# Altium schematic sheet MCU_USB.SchDoc
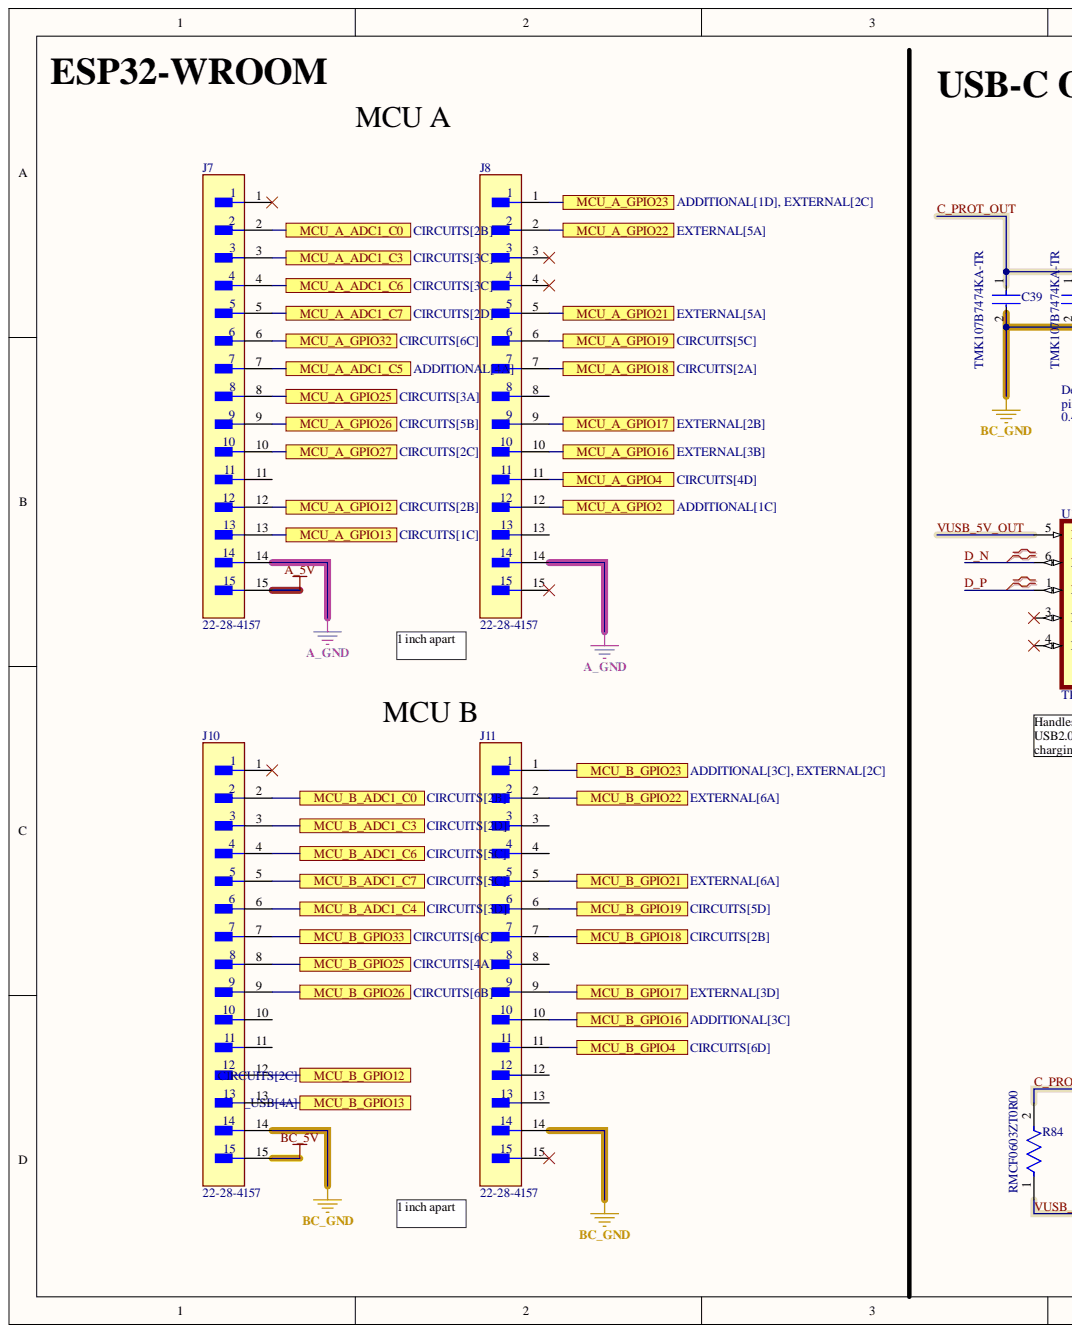
[diagram p0: MCU_USB.SchDoc, left]
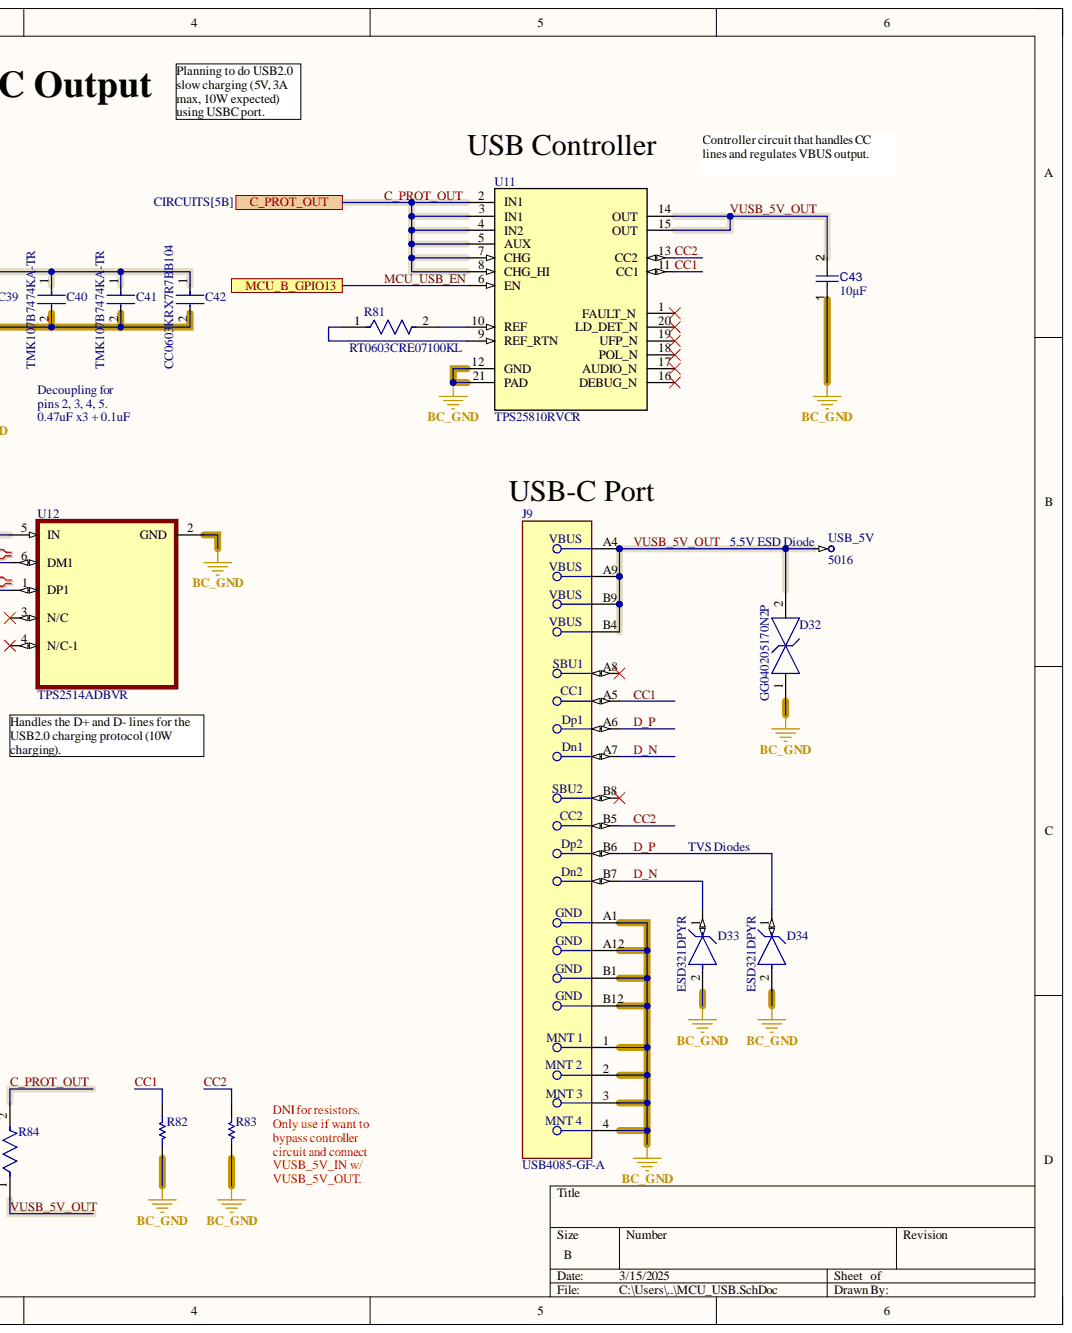
[diagram p1: MCU_USB.SchDoc, right]

## Parts
C39  TMK107B7474KA-TR  [FP-0603-L_1_6_0_1-W_0_8_0-MFG]
C40  TMK107B7474KA-TR  [FP-0603-L_1_6_0_1-W_0_8_0-MFG]
C41  TMK107B7474KA-TR  [FP-0603-L_1_6_0_1-W_0_8_0-MFG]
C42  CC0603KRX7R7BB104  [FP-CC0603-DA-MFG]
C43  value 10µF  [CAPC0603(1608)100_L]  (hidden comment: Capacitor 10µF +/-20% 10V 0603)
D32  GG040205170N2P  [FP-GG0402-MFG]
D33  ESD321DPYR  [FP-DPY0002A-MFG]
D34  ESD321DPYR  [FP-DPY0002A-MFG]
J7  22-28-4157  [FP-22-28-4157-MFG]
J8  22-28-4157  [FP-22-28-4157-MFG]
J9  USB4085-GF-A  [FP-USB4085-GF-A-MFG]
J10  22-28-4157  [FP-22-28-4157-MFG]
J11  22-28-4157  [FP-22-28-4157-MFG]
R81  RT0603CRE07100KL  [FP-RT0603-MFG]
R82  RG1608N-512-W-T1  [RESC1608X50X30LL20T20]  (value not drawn)
R83  RG1608N-512-W-T1  [RESC1608X50X30LL20T20]  (value not drawn)
R84  RMCF0603ZT0R00  [FP-RMCF0603-MFG]
U11  TPS25810RVCR  [RVC0020A]
U12  TPS2514ADBVR  [SOT95P280X145-6N]
USB_5V  5016  [KEYSTONE-5016_V]

## Ports
C_PROT_OUT, MCU_A_ADC1_C0, MCU_A_ADC1_C3, MCU_A_ADC1_C5, MCU_A_ADC1_C6, MCU_A_ADC1_C7, MCU_A_GPIO2, MCU_A_GPIO4, MCU_A_GPIO12, MCU_A_GPIO13, MCU_A_GPIO16, MCU_A_GPIO17, MCU_A_GPIO18, MCU_A_GPIO19, MCU_A_GPIO21, MCU_A_GPIO22, MCU_A_GPIO23, MCU_A_GPIO25, MCU_A_GPIO26, MCU_A_GPIO27, MCU_A_GPIO32, MCU_B_ADC1_C0, MCU_B_ADC1_C3, MCU_B_ADC1_C4, MCU_B_ADC1_C6, MCU_B_ADC1_C7, MCU_B_GPIO4, MCU_B_GPIO12, MCU_B_GPIO13, MCU_B_GPIO16, MCU_B_GPIO17, MCU_B_GPIO18, MCU_B_GPIO19, MCU_B_GPIO21, MCU_B_GPIO22, MCU_B_GPIO23, MCU_B_GPIO25, MCU_B_GPIO26, MCU_B_GPIO33

## Nets
A_5V: J7.15
A_GND: J7.14 J8.14
BC_5V: J10.15
BC_GND: C39.2 C40.2 C41.2 C42.2 C43.1 D32.1 D33.2 D34.2 J9.1 J9.2 J9.3 J9.4 J9.A1 J9.A12 J9.B1 J9.B12 J10.14 J11.14 R82.1 R83.1 U11.12(GND) U11.21(PAD) U12.2(GND)
C_PROT_OUT: C39.1 C40.1 C41.1 C42.1 R84.2 U11.2(IN1) U11.3(IN1) U11.4(IN2) U11.5(AUX) U11.7(CHG) U11.8(CHG_HI) ; port C_PROT_OUT
CC1: J9.A5 R82.2 U11.11(CC1)
CC2: J9.B5 R83.2 U11.13(CC2)
D_N: D33.1 J9.A7 J9.B7 U12.6(DM1)
D_P: D34.1 J9.A6 J9.B6 U12.1(DP1)
MCU_A_ADC1_C0: J7.2 ; port MCU_A_ADC1_C0
MCU_A_ADC1_C3: J7.3 ; port MCU_A_ADC1_C3
MCU_A_ADC1_C5: J7.7 ; port MCU_A_ADC1_C5
MCU_A_ADC1_C6: J7.4 ; port MCU_A_ADC1_C6
MCU_A_ADC1_C7: J7.5 ; port MCU_A_ADC1_C7
MCU_A_GPIO2: J8.12 ; port MCU_A_GPIO2
MCU_A_GPIO4: J8.11 ; port MCU_A_GPIO4
MCU_A_GPIO12: J7.12 ; port MCU_A_GPIO12
MCU_A_GPIO13: J7.13 ; port MCU_A_GPIO13
MCU_A_GPIO16: J8.10 ; port MCU_A_GPIO16
MCU_A_GPIO17: J8.9 ; port MCU_A_GPIO17
MCU_A_GPIO18: J8.7 ; port MCU_A_GPIO18
MCU_A_GPIO19: J8.6 ; port MCU_A_GPIO19
MCU_A_GPIO21: J8.5 ; port MCU_A_GPIO21
MCU_A_GPIO22: J8.2 ; port MCU_A_GPIO22
MCU_A_GPIO23: J8.1 ; port MCU_A_GPIO23
MCU_A_GPIO25: J7.8 ; port MCU_A_GPIO25
MCU_A_GPIO26: J7.9 ; port MCU_A_GPIO26
MCU_A_GPIO27: J7.10 ; port MCU_A_GPIO27
MCU_A_GPIO32: J7.6 ; port MCU_A_GPIO32
MCU_B_ADC1_C0: J10.2 ; port MCU_B_ADC1_C0
MCU_B_ADC1_C3: J10.3 ; port MCU_B_ADC1_C3
MCU_B_ADC1_C4: J10.6 ; port MCU_B_ADC1_C4
MCU_B_ADC1_C6: J10.4 ; port MCU_B_ADC1_C6
MCU_B_ADC1_C7: J10.5 ; port MCU_B_ADC1_C7
MCU_B_GPIO4: J11.11 ; port MCU_B_GPIO4
MCU_B_GPIO12: J10.12 ; port MCU_B_GPIO12
MCU_B_GPIO16: J11.10 ; port MCU_B_GPIO16
MCU_B_GPIO17: J11.9 ; port MCU_B_GPIO17
MCU_B_GPIO18: J11.7 ; port MCU_B_GPIO18
MCU_B_GPIO19: J11.6 ; port MCU_B_GPIO19
MCU_B_GPIO21: J11.5 ; port MCU_B_GPIO21
MCU_B_GPIO22: J11.2 ; port MCU_B_GPIO22
MCU_B_GPIO23: J11.1 ; port MCU_B_GPIO23
MCU_B_GPIO25: J10.8 ; port MCU_B_GPIO25
MCU_B_GPIO26: J10.9 ; port MCU_B_GPIO26
MCU_B_GPIO33: J10.7 ; port MCU_B_GPIO33
MCU_USB_EN: J10.13 U11.6(EN) ; port MCU_B_GPIO13
VUSB_5V_OUT: C43.2 D32.2 J9.A4 J9.A9 J9.B4 J9.B9 R84.1 U11.14(OUT) U11.15(OUT) U12.5(IN) USB_5V.1
NetR81_1: R81.1 U11.9(REF_RTN)
NetR81_2: R81.2 U11.10(REF)

Not connected: J7 1 11; J8 3 4 8 13 15; J9 A8 B8; J10 1 10 11; J11 3 4 8 12 13 15; U11 1(FAULT_N) 16(DEBUG_N) 17(AUDIO_N) 18(POL_N) 19(UFP_N) 20(LD_DET_N); U12 3(N/C) 4(N/C-1)

## Library data of the parts
C39-C41 = CMP-14477-003640-2: CAP CER 0.47UF 25V X7R 0603; Capacitance: 470nF; Tolerance: 10%; Type: General Purpose; Case Code (Imperial): 0603; Case Code (Metric): 1608; Case/Package: 0603; Component Kind: Standard; Depth: 0.8mm; Dielectric: X7R; Halogen Free: Halogen Free; Height: 0.8mm; Lead Free: Lead Free; Length: 1.6mm; Library Name: Altium Content Vault; Max Operating Temperature: 125°C; Min Operating Temperature: -55°C; Mount: Surface Mount; Number of Pins: 2; Package Quantity: 4000; Packaging: Cut Tape; Radiation Hardening: No; Resistor Type: Ceramic; RoHS Compliant: Yes; Series: M; Termination: Standard; Thickness: 0.035inch; Voltage: 25V; Voltage Rating: 25V; Voltage Rating (DC): 25V; Weight: 7.1E-05oz; Width: 0.8mm
C42 = CMP-2000-05002-2: CAP CER 0.1UF 16V X7R 0603; Manufacturer: YAGEO; Capacitance: 100 nF; Material: Ceramic; Temperature Coefficient: 15%; Tolerance: 0.1; Value: 100 nF; Automotive: No; Category: Cap; Component Kind: Standard; ComponentLink2Description: Datasheet; ComponentLink2URL: http://www.yageo.com/documents/recent/UPY-GPHC_X7R_6.3V-to-50V_18.pdf; Device Class L1: Passive Components; Device Class L2: Capacitors; Device Class L3: Ceramic Capacitors; Digikey Description: CAP CER 0.1UF 16V X7R 0603; Footprint Url: http://www.yageo.com/exep/pages/download/literatures/UPY-C_GEN_24.pdf; Height: 0.9mm; Lead Free: Yes; Library Name: Altium Content Vault; Manufacturer Part Number: CC0603KRX7R7BB104; Mouser Description: Multilayer Ceramic Capacitor, CC Series, 0.1 - F, - 10%, X7R, 16 V, 0603 [1608 Metric]; Octopart: https://octopart.com/cc0603krx7r7bb104-yageo-192941; Package: CC0603-DA; RoHS: TRUE; Temperature: -55°C to +125°C; Temperature Characteristic: X7R; Temperature Range High: +125°C; Temperature Range Low: -55°C; Voltage: 16 V; Voltage Rating: 16 V
C43 = CMP-001-00075-4: Chip Capacitor, 10µF +/-20%, 10V, 0603, Thickness 1 mm; Tolerance: 10%; Case/Package: 0603; Component Kind: Standard; Max Operating Temperature: 85°C; Min Operating Temperature: -55°C; Mounting Technology: SMT; Pins: 2; Voltage Rating: 10V; Library Name: PCB Lab - Cooper Union
D32 = CMP-04587-000002-1: TVS DIODE 5V 10V 0402; Manufacturer: AVX; Breakdown Voltage: 5.1V; Capacitance: 17pF; Direction: Bidirectional; Number Of Channels: 1; Peak Pulse Current: 8A; Peak Pulse Power: 80W; Value: 17pF; Automotive: No; Category: Diode; Clamping Voltage: 10V; Component Kind: Standard; ComponentLink2Description: Datasheet; ComponentLink2URL: http://datasheets.avx.com/AVX-GiGuard.pdf; Device Class L1: Circuit Protection; Device Class L2: TVS Diodes; Device Class L3: unset; Digikey Description: TVS DIODE 5V 10V 0402; Height: 0.55mm; Ipc Land Pattern Name: DIOC10060X50; Lead Free: Yes; Library Name: Altium Content Vault; Manufacturer Part Number: GG040205170N2P; Mouser Description: ESD Suppressor TVS Bi-Dir 5V 2-Pin Case 0402(1006Metric) T/R; Octopart: https://octopart.com/gg040205170n2p-avx-81066082; Package: GG0402; Reverse Standoff Voltage: 5V; RoHS: TRUE; Temperature: -55°C to +125°C; Temperature Range High: +125°C; Temperature Range Low: -55°C
D33 D34 = CMP-04989-000001-1: HDMI2.0 ESD PROTECTION; Manufacturer: Texas Instruments; Breakdown Voltage: 4.5V; Capacitance: 0.9pF; Clamping Voltage: 6.8V; Direction: Unidirectional; Number Of Channels: 1; Peak Pulse Current: 6A; Peak Pulse Power: 40W; Reverse Standoff Voltage: 3.6V; Standoff Height: 0mm; Value: 0.9pF; Ambient Temperature Range High: +125°C; Ambient Temperature Range Low: -40°C; Automotive: No; Category: Diode; Component Kind: Standard; ComponentLink2Description: Datasheet; ComponentLink2URL: http://www.ti.com/lit/ds/symlink/esd321.pdf; Device Class L1: Circuit Protection; Device Class L2: TVS Diodes; Device Class L3: unset; Digikey Description: HDMI2.0 ESD PROTECTION; Height: 0.45mm; Lead Free: Yes; Library Name: Altium Content Vault; Manufacturer Part Number: ESD321DPYR; Octopart: https://octopart.com/esd321dpyr-texas+instruments-94354200; Package: DPY0002A; RoHS: TRUE; Temperature: -40°C to +125°C; Temperature Range High: +125°C; Temperature Range Low: -40°C
J7 J8 J10 J11 = CMP-04776-000945-1: KK 100 HDR ASSY BKWY 15 CKT 30 S; Connector Type: Male Pin; Contact Resistance: 20mR; Current Rating: 4A; Gender: Male; Glow Wire Compliant: Non-compliant; Max Voltage Rating (DC): 250.25kV; Number of Circuits: 15; Pitch: 2.54mm; Voltage Rating: 250V; Color: Black; Component Kind: Standard; Contact Material: Brass; Contact Mating Length: 0.32inch; Contact Plating: Gold; Contact Type: Male Pin; Housing Color: Black; Housing Material: Thermoplastic; Lead Free: Lead Free; Library Name: Altium Content Vault; Max Operating Temperature: 75°C; Min Operating Temperature: 0°C; Mount: Through Hole; Number of Contacts: 15; Number of Positions: 15; Number of Rows: 1; Orientation: Straight; Package Quantity: 1; Packaging: Bulk; Series: KK® 42375; Termination: Solder
J9 = CMP-196119-000001-2: CONN RCPT USB2.0 TYPE C 24POS RA; Gender: Receptacle; Number of Positions: 16; Component Kind: Standard; Contact Material: Copper Alloy; Contact Plating: Gold; Library Name: Altium Content Vault; Orientation: Right Angle; USB Standard: USB 2.0
R81 = CMP-03412-029441-1: RES SMD 100K OHM 0.25% 1/10W; Manufacturer: Yageo; Power Rating: 0.1W; Resistance: 100KΩ; Temperature Coefficient: 50 ppm/°C; Tolerance: 0.0025; Value: 100KΩ; Voltage Rating: 75V; Automotive: No; Category: Res; Component Kind: Standard; ComponentLink2Description: Datasheet; ComponentLink2URL: http://www.yageo.com/documents/recent/PYu-RT_1-to-0.01_RoHS_L_9.pdf; Composition: Thin Film; Device Class L1: Passive Components; Device Class L2: Resistors; Device Class L3: Chip SMD Resistors; Digikey Description: RES SMD 100K OHM 0.25% 1/10W; Footprint Url: http://www.yageo.com/exep/pages/download/literatures/PYu-R_Mount_10.pdf; Height: 0.55mm; Ipc Land Pattern Name: RESC16080X45; Lead Free: Yes; Library Name: Altium Content Vault; Manufacturer Part Number: RT0603CRE07100KL; Octopart: https://octopart.com/rt0603cre07100kl-yageo-1370744; Package: RT0603; RoHS: TRUE; Series: RT; Temperature: -55°C to +155°C; Temperature Range High: +155°C; Temperature Range Low: -55°C
R82 R83 = CMP-2000-02899-1: Manufacturer: Susumu; Component Kind: Standard; Library Name: Altium Content Vault; Part Number: RG1608N-512-W-T1
R84 = CMP-26527-000011-1: RES 0 OHM JUMPER 1/10W 0603; Manufacturer: Stackpole; Automotive Grade: Grade 0; Power Rating: 0.1W; Resistance: 0mΩ; Value: 0mΩ; Voltage Rating: 75V; Automotive: Yes; Category: Res; Component Kind: Standard; ComponentLink2Description: Datasheet; ComponentLink2URL: https://www.seielect.com/catalog/sei-rmcf_rmcp.pdf; Composition: Thick Film; Device Class L1: Passive Components; Device Class L2: Resistors; Device Class L3: Chip SMD Resistors; Digikey Description: RES 0 OHM JUMPER 1/10W 0603; Height: 0.55mm; Ipc Land Pattern Name: RESC15580X45; Lead Free: Yes; Library Name: Altium Content Vault; Manufacturer Part Number: RMCF0603ZT0R00; Octopart: https://octopart.com/rmcf0603zt0r00-stackpole+electronics-19213618; Package: RMCF0603; RoHS: TRUE; Series: RMCF; Temperature: -55°C to +155°C; Temperature Range High: +155°C; Temperature Range Low: -55°C
U11 = CMP-032-000001-4: No Description Available; Mfr_Name: Texas Instruments; Component Kind: Standard; Design Item ID: TPS25810RVCR; RefDes: RefDes; Source: TPS25810RVCR.SchLib; TYPE: TYPE; Library Name: PCB Lab - Cooper Union
U12 = TPS2514ADBVR: USB dedicated charging port controller - Gen 2; MF: Texas Instruments; Availability: In Stock; Check_prices: https://www.snapeda.com/parts/TPS2514ADBVR/Texas+Instruments/view-part/?ref=eda; Component Kind: Standard; Library Name: TPS2514ADBVR.IntLib; MP: TPS2514ADBVR; Package: SOT-23-6 Texas Instruments; Price: None; Purchase-URL: https://pricing.snapeda.com/search/part/TPS2514ADBVR/?ref=eda; SnapEDA_Link: https://www.snapeda.com/parts/TPS2514ADBVR/Texas+Instruments/view-part/?ref=snap
USB_5V = CMP-2000-05178-1: Test Point, 1-Pin SMT, RoHS, Tape and Reel; Manufacturer: Keystone; Catalog Drawings: 5016Top    5016Side    5016Front; Featured Product: SurfaceMountTestPoints    5019MiniatureSurfaceMountTestPoint; Online Catalog: SurfaceMountTestPoints; Other Names: 5016KDKR; Category: TestandMeasurement; Component Kind: Standard; Datasheet URL: https://ciiva.com/datasheet-click-2917613?src=ciiva&sig=4a4e90f; Datasheet Version: Rev. D, 03/2014; Family: TestPoints; Library Name: Altium Content Vault; Manufacturer URL: http://www.keyelco.com/; Material: PhosphorBronze; Mounting Type: SurfaceMount; Package Description: 1-Pin Test Point, Body 3.81 x 2.04 mm; Package Reference: 5016; Packaging: Digi-Reel; RoHS Information: RoHSComplianceStatement; Standard Package: 1; Terminal: 0.015"(0.38mm)PhosphorBronze,SilverPlated; Type: PCTestPoint,Compact
